# Altium schematic sheet BT WiFi Power Reset Control.SchDoc
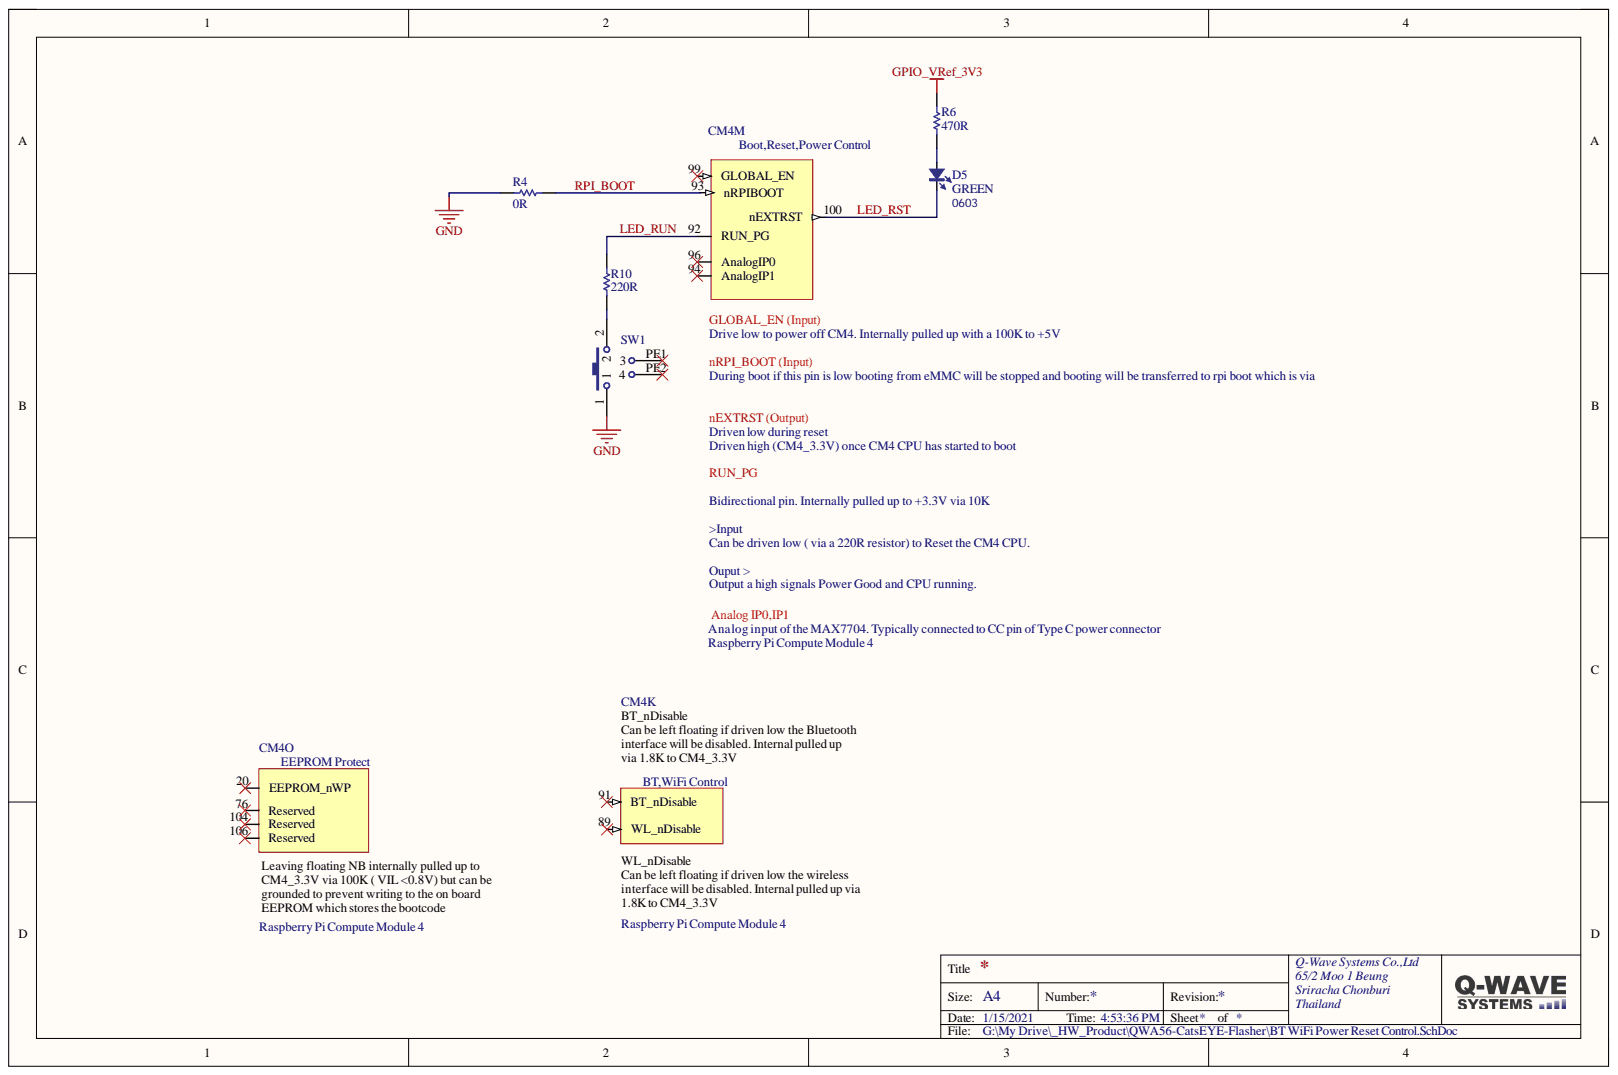
[diagram p0: BT WiFi Power Reset Control.SchDoc]

## Parts
CM4  Raspberry Pi Compute Module 4  [CM4-F40C-100DS-0.4v_1.5mm_0mm]  (parts K,M,O)
D5  GREEN  [LED_0603_BLUE]
R4  0R  [RES_0603]
R6  470R  [RES_0603]
R10  220R  [RES_0603]
SW1  TS36CA-0.7  [SW-SMD_TS36CA]  (value not drawn)

## Nets
GND: R4.2 SW1.1
GPIO_VRef_3V3: R6.2
LED_RST: CM4.100 D5.2
LED_RUN: CM4.92(RUN_PG) R10.2
RPI_BOOT: CM4.93(nRPIBOOT) R4.1
NetD5_1: D5.1 R6.1
NetR10_1: R10.1 SW1.2

Not connected: CM4 20(EEPROM_nWP) 76(Reserved) 89(WL_nDisable) 91(BT_nDisable) 94(AnalogIP1) 96(AnalogIP0) 99(GLOBAL_EN) 104(Reserved) 106(Reserved); SW1 PE1 PE2

## Library data of the parts
CM4 = CM4: Component Kind: Standard; Description: Raspberry Pi Compute Module 4; Library Name: CM4.SchLib
D5 = LED_0603: LED; Type: 0603; Value: color?; Component Kind: Standard; Library Name: SCH_Active.SchLib
R4 R10 = Res Semi: Resistor 0603; Component Kind: Standard; Library Name: SCH_Passive.SchLib; Signal Integrity: Res Semi; Simulation: RES
R6 = Res Semi: 470R Resistor 0603; Component Kind: Standard; Library Name: SCH_Passive.SchLib; Signal Integrity: Res Semi; Simulation: RES
SW1 = SW-PB: SPST 50mA @ 12VDC Side operation Rectangle button 250±50gf SMD Tactile Switches RoHS; MFG: SHOU HAN; Component Kind: Standard; MFG P/N: TS36CA-0.7; Supplier_Part_Number: C412365; Supplier_Purchase_URL: https://lcsc.com/product-detail/Tactile-Switches_SHOU-HAN-TS36CA-0-7_C412365.html; Library Name: QwaveLib.IntLib
